# Altium schematic sheet DAC & MIC.SchDoc
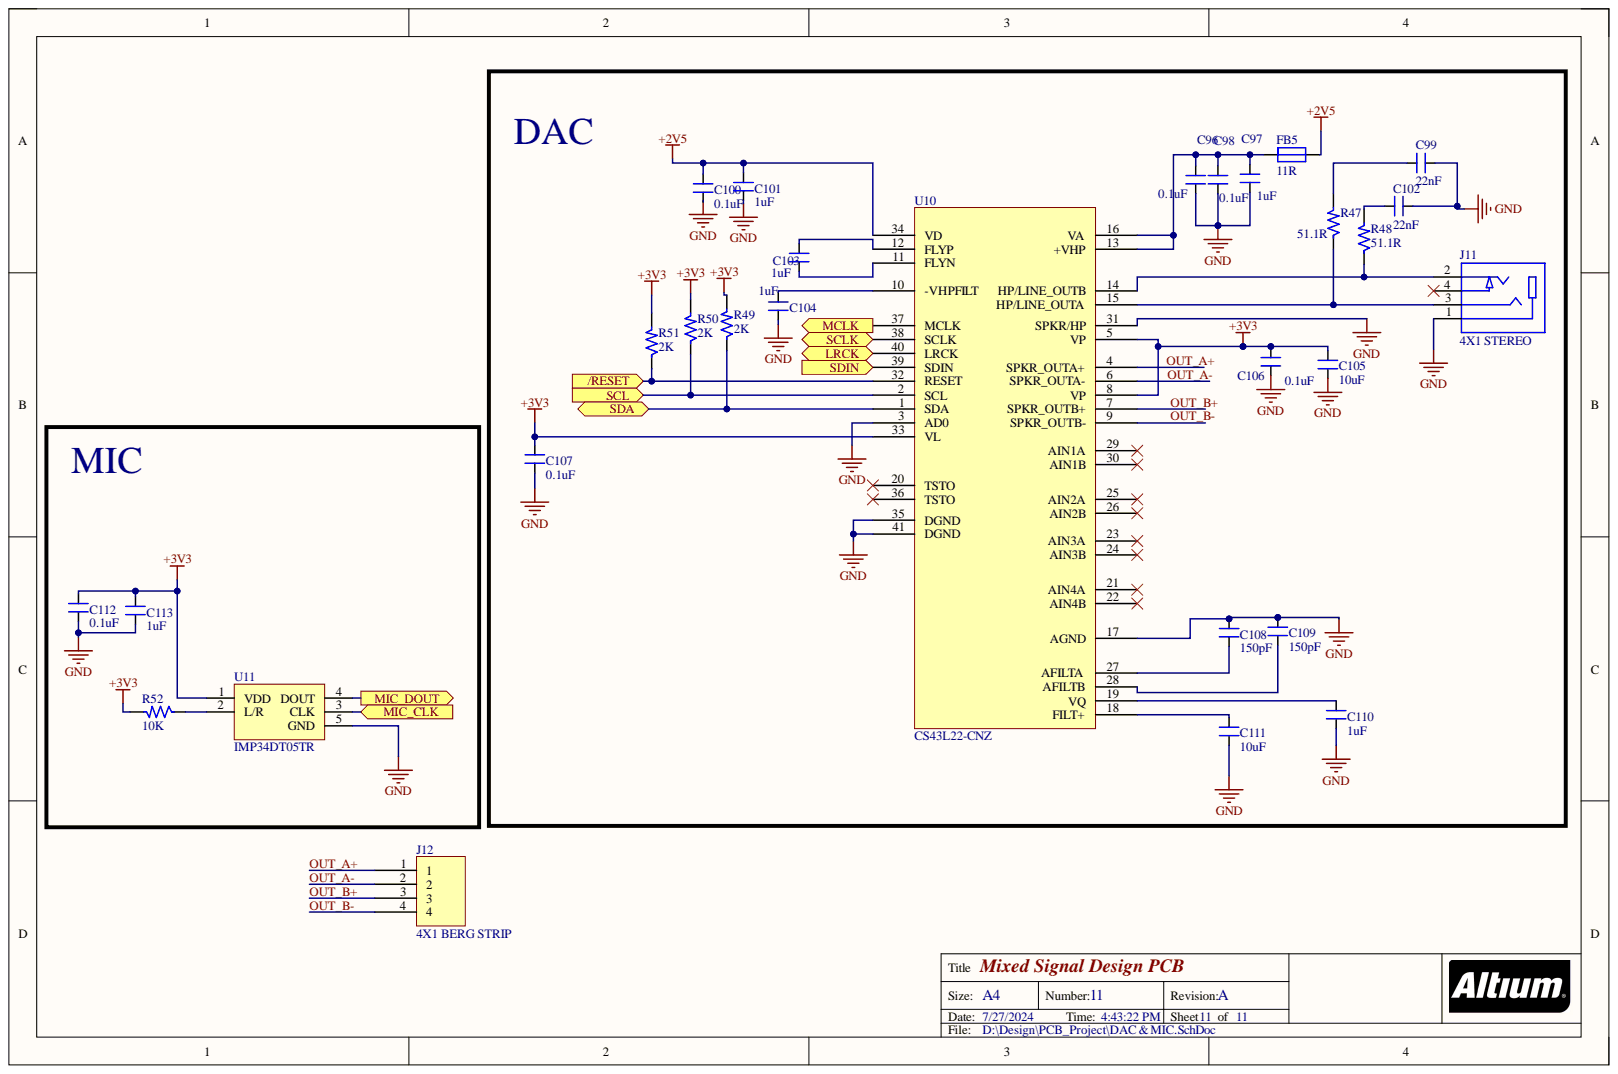
[diagram p0: DAC & MIC.SchDoc]

## Parts
C96  0.1uF  [CAP_0805]
C97  1uF  [CAP_0805]
C98  0.1uF  [CAP_0805]
C99  22nF  [CAP_0805]
C100  0.1uF  [CAP_0805]
C101  1uF  [CAP_0805]
C102  22nF  [CAP_0805]
C103  1uF  [CAP_0805]
C104  1uF  [CAP_0805]
C105  10uF  [CAP_1206]
C106  0.1uF  [CAP_0805]
C107  0.1uF  [CAP_0805]
C108  150pF  [CAP_0805]
C109  150pF  [CAP_0805]
C110  1uF  [CAP_0805]
C111  10uF  [CAP_1206]
C112  0.1uF  [CAP_0805]
C113  1uF  [CAP_0805]
FB5  11R  [IND_0805]
J11  4X1 STEREO  [CON_SJ-2504A-SMT-TR_SMD]
J12  4X1 BERG STRIP  [CON4_100_40D72_TH_ST_MALE]
R47  51.1R  [RES_0603]
R48  51.1R  [RES_0603]
R49  2K  [RES_0805]
R50  2K  [RES_0805]
R51  2K  [RES_0805]
R52  10K  [RES_0805]
U10  CS43L22-CNZ  [QFN40_19_284_33X9_SMD]
U11  IMP34DT05TR  [HCLGA-4_53_137_15X37_SMD]

## Ports
/RESET, LRCK, MCLK, MIC_CLK, MIC_DOUT, SCL, SCLK, SDA, SDIN

## Nets
+2V5: C100.1 C101.2 FB5.2 U10.34(VD)
+3V3: C105.2 C106.1 C107.1 C112.1 C113.2 R49.2 R50.2 R51.2 R52.2 U10.5(VP) U10.8(VP) U10.33(VL) U11.1(VDD)
GND: C96.2 C97.1 C98.2 C99.1 C100.2 C101.1 C102.1 C104.1 C105.1 C106.2 C107.2 C108.2 C109.2 C110.1 C111.1 C112.2 C113.1 J11.1 U10.3(AD0) U10.17(AGND) U10.31(SPKR/HP) U10.35(DGND) U10.41(DGND) U11.5(GND)
/RESET: R51.1 U10.32(RESET) ; port /RESET
LRCK: U10.40(LRCK) ; port LRCK
MCLK: U10.37(MCLK) ; port MCLK
MIC_CLK: U11.3(CLK) ; port MIC_CLK
MIC_DOUT: U11.4(DOUT) ; port MIC_DOUT
OUT_A+_11: J12.1 U10.4(SPKR_OUTA+)  [also labelled OUT_A+]
OUT_A-_11: J12.2 U10.6(SPKR_OUTA-)  [also labelled OUT_A-]
OUT_B+_11: J12.3 U10.7(SPKR_OUTB+)  [also labelled OUT_B+]
OUT_B-_11: J12.4 U10.9(SPKR_OUTB-)  [also labelled OUT_B-]
SCL: R50.1 U10.2(SCL) ; port SCL
SCLK: U10.38(SCLK) ; port SCLK
SDA: R49.1 U10.1(SDA) ; port SDA
SDIN: U10.39(SDIN) ; port SDIN
NetC96_1: C96.1 C97.2 C98.1 FB5.1 U10.13(+VHP) U10.16(VA)
NetC99_2: C99.2 R47.2
NetC102_2: C102.2 R48.2
NetC103_1: C103.1 U10.11(FLYN)
NetC103_2: C103.2 U10.12(FLYP)
NetC104_2: C104.2 U10.10(-VHPFILT)
NetC108_1: C108.1 U10.27(AFILTA)
NetC109_1: C109.1 U10.28(AFILTB)
NetC110_2: C110.2 U10.19(VQ)
NetC111_2: C111.2 U10.18(FILT+)
NetJ11_2: J11.2 R48.1 U10.14(HP/LINE_OUTB)
NetJ11_3: J11.3 R47.1 U10.15(HP/LINE_OUTA)
NetJ11_4: J11.4
NetR52_1: R52.1 U11.2(L/R)
NetU10_20: U10.20(TSTO)
NetU10_21: U10.21(AIN4A)
NetU10_22: U10.22(AIN4B)
NetU10_23: U10.23(AIN3A)
NetU10_24: U10.24(AIN3B)
NetU10_25: U10.25(AIN2A)
NetU10_26: U10.26(AIN2B)
NetU10_29: U10.29(AIN1A)
NetU10_30: U10.30(AIN1B)
NetU10_36: U10.36(TSTO)

## Library data of the parts
C96 C98 C100 C106 C107 C112 = CAP_0.1uF-50V-5%-0805: 0.1µF ±5% 50V Ceramic Capacitor X7R 0805 (2012 Metric); Alternate Part Number-1: 0805B104M500; Manufacturer: AVX Corporation; Manufacturer Part Number: 08055C104JAT4A; Case/Package: 0805; Component Kind: Standard; Library Name: ESTEEMPCBLIB.IntLib; Supplier Part Number-1: 0805B104J500CT; Supplier-1: SIRICOM
C97 C101 C103 C104 C110 C113 = CAP_1uF-50V-5%-0805: 1µF ±5% 50V Ceramic Capacitor X7R 0805 (2012 Metric); Alternate Part Number-1: 0805F105M500NT; Manufacturer: Samsung; Manufacturer Part Number: CC0805JKX7R9BB105; Case/Package: 0805; Component Kind: Standard; Library Name: ESTEEMPCBLIB.IntLib; Supplier Part Number-1: CL21B105KBFNNNE; Supplier-1: SIRICOM
C99 C102 = CAP_22nF-50V-10%-0805: 0.022µF ±10% 50V Ceramic Capacitor X7R 0805 (2012 Metric); Alternate Part Number-1: NA; Manufacturer: Samsung Electro-Mechanics; Manufacturer Part Number: CL21B223KBANNNC; Case/Package: 0805; Component Kind: Standard; Library Name: ESTEEMPCBLIB.IntLib; Supplier Part Number-1: 1276-1081-1-ND - Cut Tape (CT); Supplier-1: Digikey
C105 C111 = CAP_10uF-50V-10%-1206: 10µF ±10% 50V Ceramic Capacitor X7R 1206 (3216 Metric); Alternate Part Number-1: GRT31CR61H106ME01L; Manufacturer: KEMET; Manufacturer Part Number: CL31B106KBHNNNE; Case/Package: 1206; Component Kind: Standard; Library Name: ESTEEMPCBLIB.IntLib; Supplier Part Number-1: CC1206KKX5R9BB106; Supplier-1: SIRICOM
C108 C109 = CAP_150pF-50V-10%-0805: 150pF ±10% 50V Ceramic Capacitor C0G, NP0 0805 (2012 Metric); Alternate Part Number-1: NA; Manufacturer: AVX Corporation; Manufacturer Part Number: 08055A151KAT2A; Case/Package: 0805; Component Kind: Standard; Library Name: ESTEEMPCBLIB.IntLib; Supplier Part Number-1: 478-10501-1-ND - Cut Tape (CT); Supplier-1: Digikey
FB5 = FB_11R-100MHZ-1.5A-0805: 11 Ohms @ 100MHz 1 Power Line Ferrite Bead 0805 (2012 Metric) 1.5A 60mOhm; Alternate Part Number-1: NA; Manufacturer: Murata; Manufacturer Part Number: MI0805K110R-10; Case/Package: 0805; Component Kind: Standard; Library Name: ESTEEMPCBLIB.IntLib; Supplier Part Number-1: ACMS201209A110; Supplier-1: SIRICOM
J11 = CON-PLG-STEREO_4X1-100-RA-SMD: 2.50mm (0.094", 3/32", Sub Mini, Miniature) - Headphone Phone Jack Stereo (4 Conductor, TRRS) Connector Solder; Alternate Part Number-1: NA; Manufacturer: CUI Devices; Manufacturer Part Number: SJ-2504A-SMT-TR; Case/Package: SMD; Component Kind: Standard; Library Name: ESTEEMPCBLIB.IntLib; Supplier Part Number-1: CP-SJ-2504A-SMT-CT-ND - Cut Tape (CT); Supplier-1: Digikey
J12 = CON-BS-PLG_4X1-100-ST-TH: 4 Pins, 1 Row 2.54mm Pitch, Straight bergstrip, Male; Alternate Part Number-1: NA; Case/Package: TH-CON; Component Kind: Standard; Library Name: ESTEEMPCBLIB.IntLib; Manufacturer: NA; Manufacturer Part Number: NA; Supplier Part Number-1: NA; Supplier-1: SP ROAD
R47 R48 = RES_51.1R-0.1W-1%-0603: 51.1 Ohms ±1% 0.1W, 1/10W Chip Resistor 0603 (1608 Metric) Thick Film; Alternate Part Number-1: NA; Manufacturer: Vishay Dale; Manufacturer Part Number: CRCW060351R1FKEAC; Case/Package: 0603; Component Kind: Standard; Library Name: ESTEEMPCBLIB.IntLib; Supplier Part Number-1: 541-5468-1-ND - Cut Tape (CT); Supplier-1: Digikey
R49-R51 = RES_2K-0.125W-1%-0805: 2 kOhms ±1% 0.125W, 1/8W Chip Resistor 0805 (2012 Metric) Moisture Resistant Thick Film; Alternate Part Number-1: NA; Manufacturer: TE Connectivity; Manufacturer Part Number: RC0805FR-072KL; Case/Package: 0805; Component Kind: Standard; Library Name: ESTEEMPCBLIB.IntLib; Supplier Part Number-1: WR08X2001FTL; Supplier-1: SIRICOM
R52 = RES_10K-0.125W-1%-0805: 10 kOhms ±1% 0.125W, 1/8W Chip Resistor 0805 (2012 Metric) Automotive AEC-Q200 Thick Film; Alternate Part Number-1: WR08X1002FTL; Manufacturer: CTS; Case/Package: 0805; Component Kind: Standard; Library Name: ESTEEMPCBLIB.IntLib; Manufacturer Part Number: WR08X1002FTL; Supplier Part Number-1: WR08X1002FTL; Supplier-1: SIRICOM
U10 = IC_CS43L22: Audio D/A Converter ICs Low Power Stereo DAC w/ClassD Spkr Driver; Alternate Part Number-1: NA; Manufacturer: Cirrus Logic; Case/Package: QFN-40; Component Kind: Standard; Library Name: ESTEEMPCBLIB.IntLib; Manufacturer Part Number: CS43L22-CNZ; Supplier Part Number-1: 777-CS43L22-CNZ; Supplier-1: Mouser
U11 = IC_IMP34DT05TR: MEMS Microphones AUTO & INDUSTRIAL SENSORS; Alternate Part Number-1: NA; Manufacturer: STMicroelectronics; Case/Package: HCLGA-4; Component Kind: Standard; Library Name: ESTEEMPCBLIB.IntLib; Manufacturer Part Number: IMP34DT05TR; Supplier Part Number-1: 511-IMP34DT05TR; Supplier-1: Mouser
